# Altium schematic sheet CuC_DataComm.SchDoc
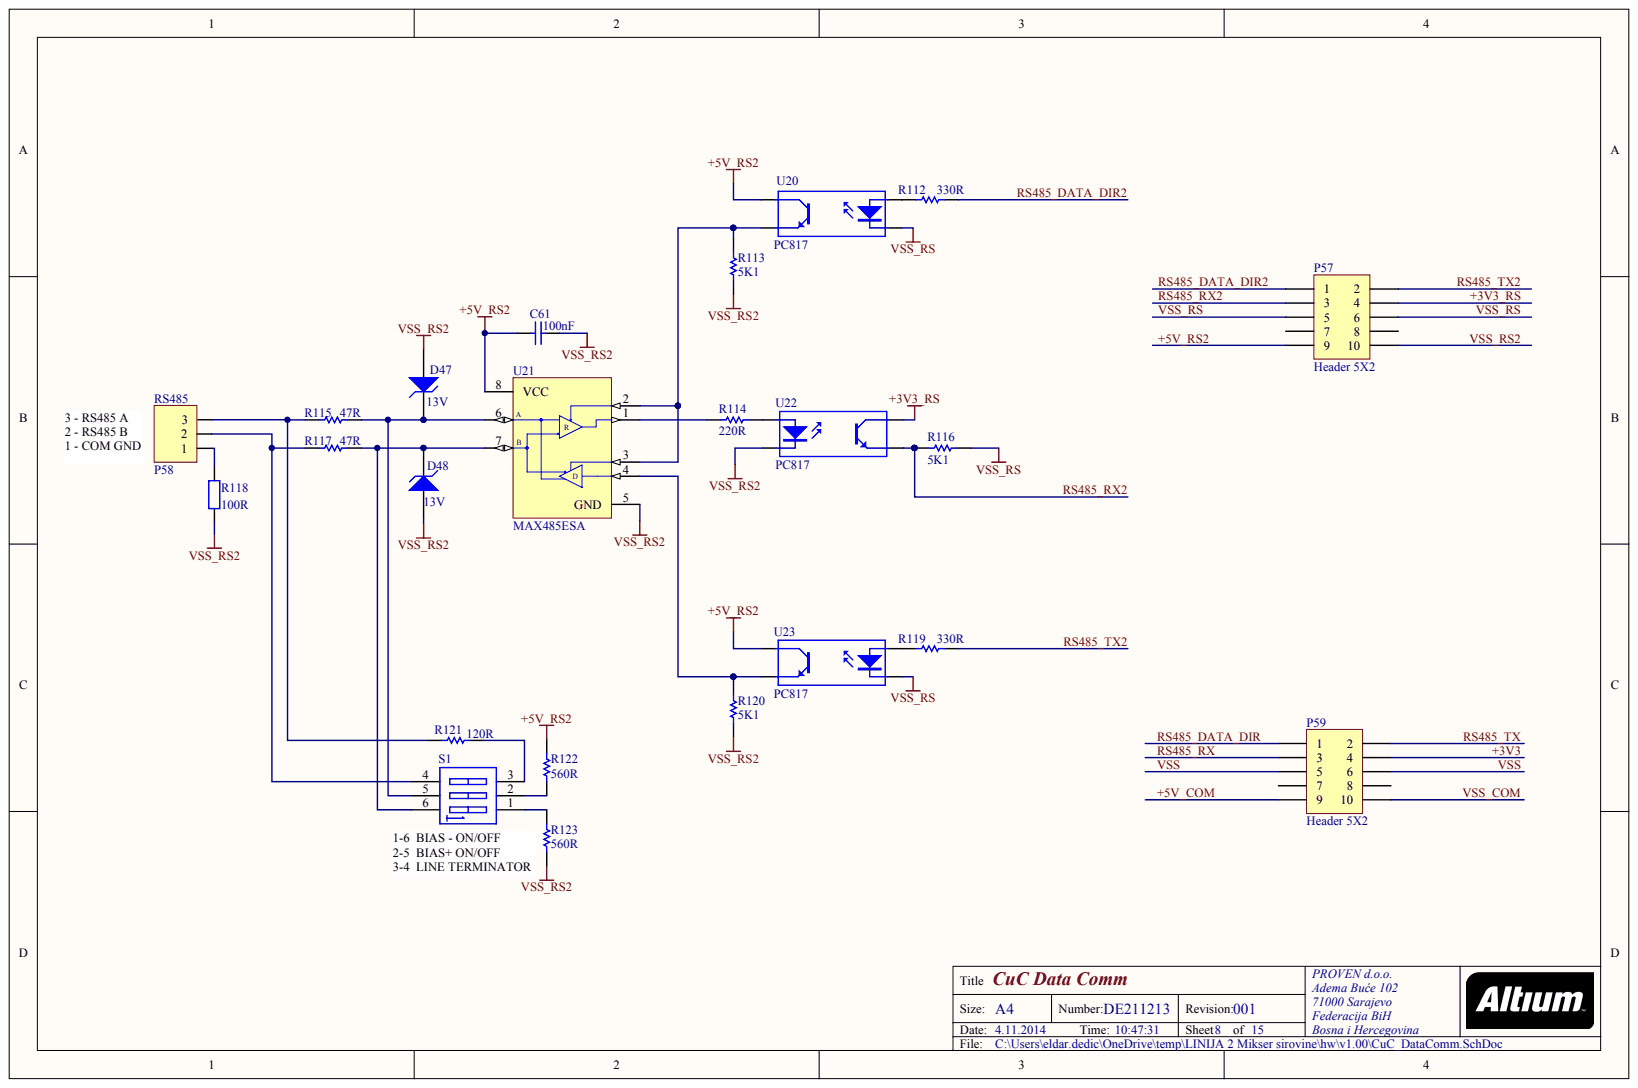
[diagram p0: CuC_DataComm.SchDoc]

## Parts
C61  100nF
D47  13V
D48  13V
P57  Header 5X2
P58  RS485
P59  Header 5X2
R112  330R
R113  5K1
R114  220R
R115  47R
R116  5K1
R117  47R
R118  100R
R119  330R
R120  5K1
R121  120R
R122  560R
R123  560R
S1  (no value)
U20  PC817
U21  MAX485ESA
U22  PC817
U23  PC817

## Nets
+3V3: P59.4
+3V3_RS: P57.4 U22.4
+5V_COM: P59.9
+5V_RS2: C61.2 P57.9 R122.2 U20.4 U21.8(VCC) U23.4
RS485_DATA_DIR: P59.1
RS485_DATA_DIR2: P57.1 R112.2
RS485_RX: P59.3
RS485_RX2: P57.3 R116.1 U22.3
RS485_TX: P59.2
RS485_TX2: P57.2 R119.2
VSS: P59.5 P59.6
VSS_COM: P59.10
VSS_RS: P57.5 P57.6 R116.2 U20.2 U23.2
VSS_RS2: C61.1 D47.1 D48.1 P57.10 R113.1 R118.1 R120.1 R123.1 U21.5(GND) U22.2
NetD47_2: D47.2 R115.2 S1.5 U21.6
NetD48_2: D48.2 R117.2 S1.6 U21.7
NetP58_1: P58.1 R118.2
NetP58_2: P58.2 R117.1 S1.4
NetP58_3: P58.3 R115.1 R121.1
NetR112_1: R112.1 U20.1
NetR113_2: R113.2 U20.3 U21.2 U21.3
NetR114_1: R114.1 U22.1
NetR114_2: R114.2 U21.1
NetR119_1: R119.1 U23.1
NetR120_2: R120.2 U21.4 U23.3
NetR121_2: R121.2 S1.3
NetR122_1: R122.1 S1.2
NetR123_2: R123.2 S1.1

Not connected: P57 7 8; P59 7 8

## Library data of the parts
R112-R117 R119-R123 = (no symbol name): Note: If flow soldering, adjust pad width of PCB Footprint to 0.7 - 0.8 times the width of the resistor.; Published: 17-Dec-2003; LatestRevisionDate: 19-Mar-2004; LatestRevisionNote: Silkscreen size reduced.; PackageDocument: Mar-2003; ComponentLink1Description: Manufacturer Link; ComponentLink1URL: http://www.panasonic.com/industrial/components/resistive_products.htm; ComponentLink2Description: Datasheet; Publisher: Altium Limited
  R112 R119: PackageReference: ERA-0805; Code_IPC: 2012 [0805]; ComponentLink2URL: http://www.panasonic.com/industrial/components/pdf/AOA0000CE8.pdf
  R113 R116 R120: PackageReference: 8-1206; Code_IPC: 3216 [1206]; ComponentLink2URL: http://www.panasonic.com/industrial/components/pdf/AOA0000CE1.pdf
  R114 R115 R117 R121-R123: PackageReference: ERA-0805; Code_IPC: 2012 [0805]; ComponentLink2URL: http://www.panasonic.com/industrial/components/pdf/AOF0000CE1.pdf
C61 D47 D48 P57-P59 R118 S1 U20-U23 = (no symbol name)
  C61: Published: 17-Jul-2002; LatestRevisionDate: 19-Mar-2004; LatestRevisionNote: Silkscreen size reduced.; DatasheetDocument: Mar-2001; PackageDocument: Mar-2001; PackageReference: 1206; Code_JEITA: 1206; Code_IPC: 3216 [1206]; ComponentLink1Description: Manufacturer Link; ComponentLink1URL: http://www.kemet.com/; ComponentLink2Description: Datasheet; ComponentLink2URL: http://www.kemet.com/kemet/web/homepage/kechome.nsf/vapubfilesname/F3102TA.pdf/$file/F3102TA.pdf
  D47 D48: Published: 8-Jun-2000; LatestRevisionDate: 17-Jul-2002; LatestRevisionNote: Re-released for DXP Platform.; PackageReference: DIODE-0.7; PackageDescription: Diode, Thru-Hole; 2 Leads; 0.7 in Pin Spacing
  P57 P59: LatestRevisionDate: 17-Jul-2002; LatestRevisionNote: Re-released for DXP Platform.
  R118: Published: 8-Jun-2000; LatestRevisionDate: 17-Jul-2002; LatestRevisionNote: Re-released for DXP Platform.; PackageReference: AXIAL-0.4; PackageDescription: Axial Device, Thru-Hole; 2 Leads; 0.4 in Pin Spacing
  S1: Published: 24-Mar-1999; LatestRevisionDate: 17-Jul-2002; LatestRevisionNote: Re-released for DXP Platform.; PackageReference: DIP-6; Code_JEDEC: MO-001-AM
  U20 U22 U23: Published: 24-Mar-1999; LatestRevisionDate: 04-Mar-2005; LatestRevisionNote: Sch pins 3 & 4 Swapped.; DatasheetVersion: Nov-2003; PackageReference: DIP-4
  U21: Published: 16-Jan-2001; LatestRevisionDate: 13-Apr-2006; LatestRevisionNote: IPC-7351 Footprint Added.; DatasheetVersion: Feb-1996; PackageReference: NSO8; PackageDescription: 8-Pin Small Outline Integrated Circuit 1.27 mm Pitch; Code_JEDEC: MS-012-AA; Code_IPC: SOIC127P600-8; ComponentLink1Description: Manufacturer Link; ComponentLink1URL: http://www.maxim-ic.com/; ComponentLink2Description: Datasheet; ComponentLink2URL: http://pdfserv.maxim-ic.com/en/ds/MAX1487-MAX491.pdf
  C61 D47 D48 P57 P59 R118 S1 U20-U23: Publisher: Altium Limited
